# Altium schematic sheet lv_power_tec_cc.SchDoc(lv_power_tec_cc)
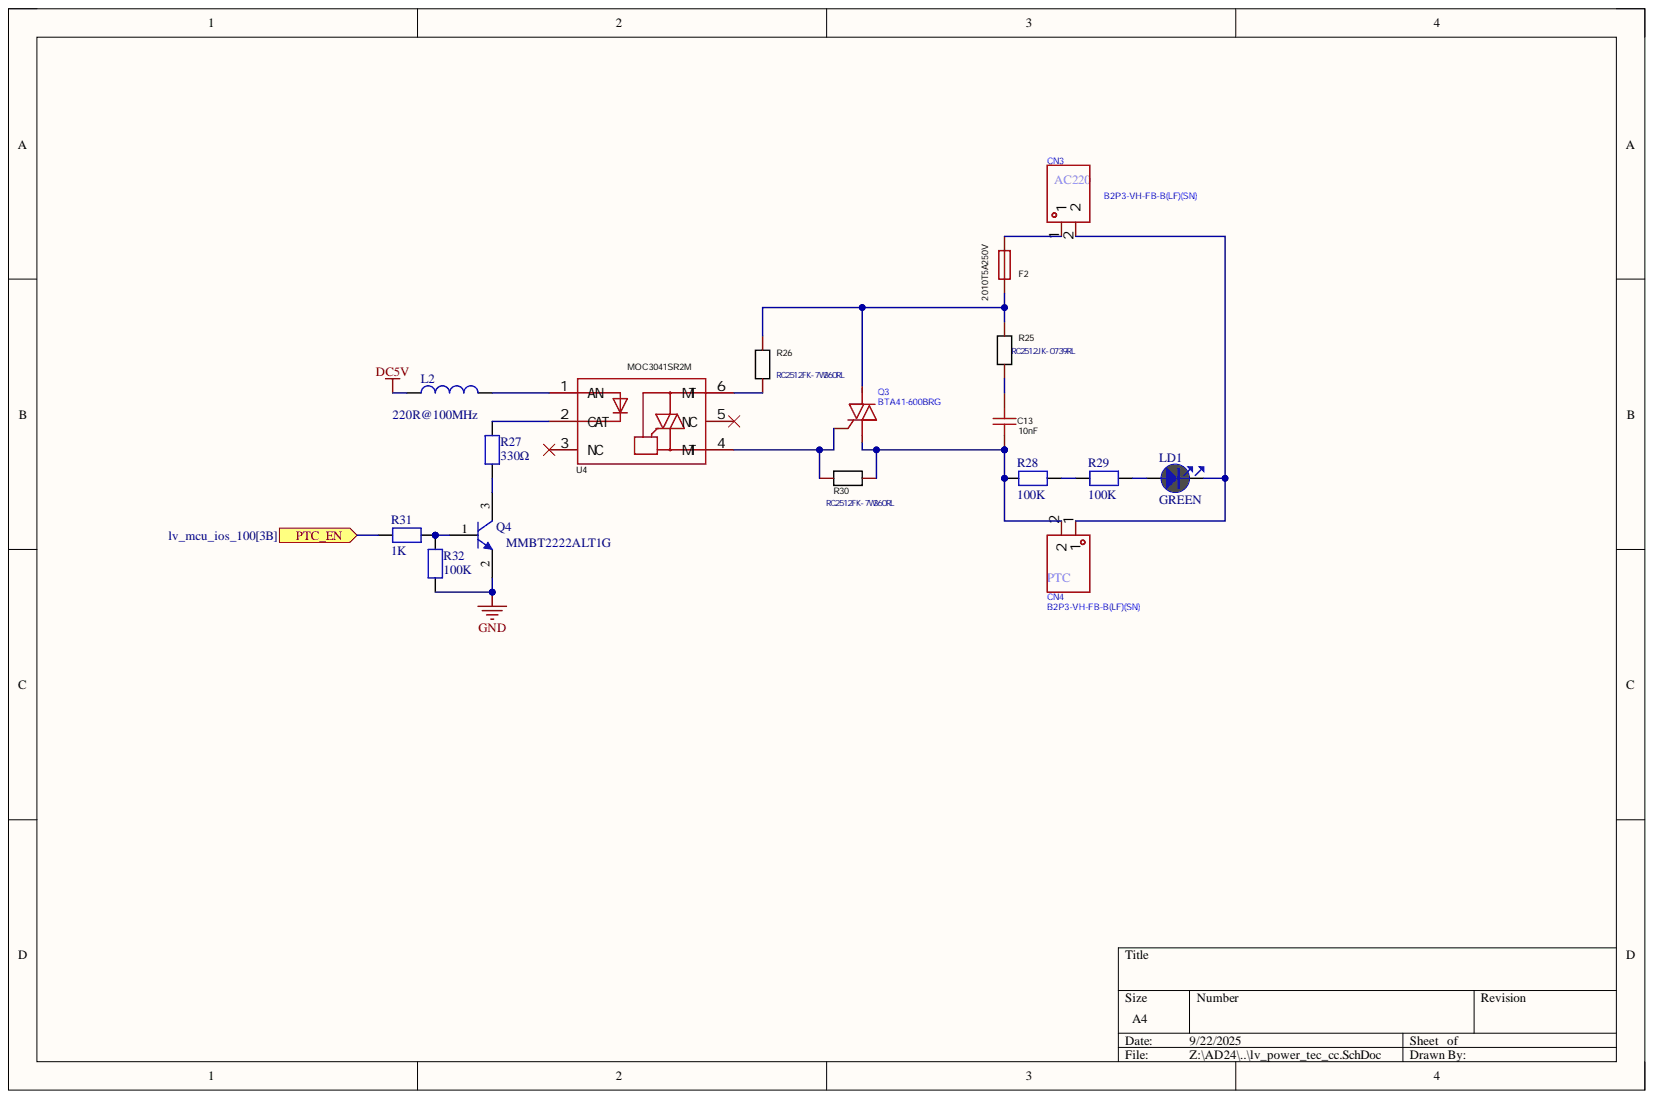
[diagram p0: lv_power_tec_cc.SchDoc]

## Parts
C13  10nF  [CAP-TH_L10.0-W6.0-P7.50-D0.6]
CN3  B2P3-VH-FB-B(LF)(SN)  [CONN-TH_2P-P7.92_B2P3-VH-FB-B-LF-SN]
CN4  B2P3-VH-FB-B(LF)(SN)  [CONN-TH_2P-P7.92_B2P3-VH-FB-B-LF-SN]
F2  2010T5A250V  [FUSE-TH_L8.5-W4.0-P5.08-D0.6]
L2  220R@100MHz  [L0603]
LD1  value GREEN  [LED_0603_GREEN]  (hidden comment: LED_green)
Q3  BTA41-600BRG  [TOP3_L15.3-W4.5-P5.60-L]
Q4  MMBT2222ALT1G  [NPN_SOT23]
R25  RC2512JK-0739RL  [R2512]
R26  RC2512FK-7W360RL  [R2512]
R27  330Ω  [R0603]
R28  100K  [C1210]
R29  100K  [C1210]
R30  RC2512FK-7W360RL  [R2512]
R31  1K  [R0402]
R32  100K  [R0402]
U4  MOC3041SR2M  [SOP-6_L8.5-W6.4-P2.54-LS9.2-BL]

## Ports
PTC_EN

## Nets
DC5V: L2.2
GND: Q4.2 R32.1
PTC_EN: R31.1 ; port PTC_EN
NetC13_1: C13.1 CN4.2 Q3.1 R28.1 R30.2
NetC13_2: C13.2 R25.2
NetCN3_1: CN3.1 F2.2
NetCN3_2: CN3.2 CN4.1 LD1.2
NetF2_1: F2.1 Q3.2 R25.1 R26.2
NetL2_1: L2.1 U4.1(AN)
NetLD1_1: LD1.1 R29.2
NetQ3_3: Q3.3 R30.1 U4.4(MT)
NetQ4_1: Q4.1 R31.2 R32.2
NetQ4_3: Q4.3 R27.2
NetR26_1: R26.1 U4.6(MT)
NetR27_1: R27.1 U4.2(CAT)
NetR28_2: R28.2 R29.1

Not connected: U4 3(NC) 5(NC)

## Library data of the parts
C13 = MMK103J2JB5KN206G0: CBB, 630V, 5%; Manufacturer: KNSCHA(科尼盛); Add into BOM: yes; Component Kind: Standard; Convert to PCB: yes; Datasheet: https://atta.szlcsc.com/upload/public/pdf/source/20220419/DB24DB252E6F1323EAB18A483F3A5AEF.pdf; Device: MMK103J2JB5KN206G0; JLCPCB Part Class: Extended Part; LCSC Part Name: 10nF ±5% 630V; Library Name: HiPin_Library_AD.IntLib; Manufacturer Part: MMK103J2JB5KN206G0; Operating Temperature: -40℃~+105℃; PCB3D: CAP-TH_L10.0-W6.0-P7.50-D0.6; Supplier: LCSC; Supplier Footprint: 插件,P=7.5mm; Supplier Part: C2998029; Symbol: MMK103J2JB5KN206G0; Tolerance: ±5%; Value: 10nF; Voltage Rated: 630V
CN3 CN4 = B2P3-VH-FB-B(LF)(SN): 7.9mm*2p; Manufacturer: JST; Add into BOM: yes; Current Rating: 10A; Flame Retardant Rating: UL94V-0; Number of PINs Per Row: 3; Pins Structure: 1x3P(缺1P); Pitch: 7.92mm; Plastic Material: 玻纤增强PBT; Reference Series: VH; Supplementary Features: 带柱；缺PIN; Symbol: B2P3-VH-FB-B-(LF)(SN); Voltage Rating: 250V; X-Length of Bottom Edge on Board (Spacing Line): 13.76mm; Y-Width of Bottom Edge on Board: 9.7mm; Z-Height of the Board: 11mm; Color: 白色; Component Kind: Standard; Contact Material: 铜合金; Contact Plating: 锡; Convert to PCB: yes; Datasheet: https://item.szlcsc.com/datasheet/B2P3-VH-FB-B(LF)(SN)/169357.html; Device: B2P3-VH-FB-B(LF)(SN); JLCPCB Part Class: Extended Part; LCSC Part Name: 1x3P(缺1P) 间距:7.92mm 直插 系列:VH; Library Name: HiPin_Library_AD.IntLib; Manufacturer Part: B2P3-VH-FB-B(LF)(SN); Mounting Type: 直插; Number of Pins: 2P(3P缺1P); Number of Rows: 1; Operating Temperature: -25℃~+85℃; PCB3D: CONN-TH_2P-P7.92_B2P3-VH-FB-B-LF-SN; Row Spacing: -; Supplier: LCSC; Supplier Footprint: 插件,P=7.92mm; Supplier Part: C158009
F2 = 2010T3.15A250V: 保险丝; Manufacturer: WALTER(华德); Add into BOM: yes; Current Rating: 3.15A; Type: 方形保险丝; Breaking Capacity: -; Clearing Time Characteristics: -; Component Kind: Standard; Convert to PCB: yes; Datasheet: https://atta.szlcsc.com/upload/public/pdf/source/20181226/C354908_56D0A636A97884A85D4A44B7DA5ABF9D.pdf; Device: 2010T3.15A250V; JLCPCB Part Class: Extended Part; LCSC Part Name: 插件式保险丝; Library Name: HiPin_Library_AD.IntLib; Manufacturer Part: 2010T3.15A250V; Operating Temperature: -; PCB3D: FUSE-TH_L8.5-W4.0-H8.5-P5.08; Supplier: LCSC; Supplier Footprint: 插件; Supplier Part: C354904; Surge and Pulse Current Charactersistics: -; Symbol: 2010T3.15A250V; Voltage Rating  (AC): -; Voltage Rating (DC): -
L2 = MCD-00047: SMD Chip ferrite bead, 220R@100MHz +/-25%,2.2A, 50mohm; Manufacturer: Sunlord; Manufacturer_Part_Number: UPZ1608U221-2R2TF; Database Table Name: MCD_PARTS$; Component Kind: Standard; ComponentLink1Description: Datasheet; ComponentLink1URL: http://tunmcdsvn.tun.st.com/Component_Datasheet_Library/Trunk/Inductors/TaiTech_Bead_FCM1608_series; Internal_Part_Number: MCD-00047; Library Name: MCD_PARTS_database.DbLib
LD1 = LED_green: LED, Green, 0603; Internal_Part_Number: MCD-00050; Manufacturer: EVERLIGHT; Manufacturer_Part_Number: 19-213SYGC/S530-E2/TR8; Part_Type: Display; ECCN_EU: NEC; ECCN_US: EAR99; Provided_By: SUBCO; Component Kind: Standard; ComponentLink1Description: Datasheet; ComponentLink1URL: http://tunmcdsvn.tun.st.com/Component_Datasheet_Library/Trunk/Display/Everlight_SMD-LED-GREEN_19-213SYGC-S530-XX-TR8-v3.doc; Library Name: mb1367.IntLib; Package: LED 0603; Status: Released
Q3 = BTA41-600BRG_C9103: 可控硅; Manufacturer: ST(意法半导体); Add into BOM: yes; Gate Trigger   Voltage (Vgt): 1.3V; Gate Trigger Current(Igt): 50mA; Holding Current (Ih): 80mA; Peak Repetitive Off?State Voltage (Vdrm): 600V; RMS On-State Current(It (rms)): 40A; Component Kind: Standard; Convert to PCB: yes; Datasheet: https://atta.szlcsc.com/upload/public/pdf/source/20180517/C221478_6AA6A4FEA4D04549221AAA28B5A1E881.pdf; Device: BTA41-600BRG_C9103; JLCPCB Part Class: Extended Part; LCSC Part Name: BTA41-600BRG; Library Name: HiPin_Library_AD.IntLib; Manufacturer Part: BTA41-600BRG; Operating Temperature: -40℃~+125℃@(Tj); PCB3D: TOP3_L15.3-W4.5-H12.8-P5.60; SCR Type: -; Supplier: LCSC; Supplier Footprint: TOP-3; Supplier Part: C9103; Symbol: BTA41-600BRG
Q4 = 9013-SOT23: 晶体管类型  NPN  集电极电流(Ic)  600mA  集射极击穿电压(Vceo)  40V  耗散功率(Pd)  225mW; Manufacturer: onsemi(安森美); Component Kind: Standard; Library Name: HiPin_Library_AD.IntLib; Manufacturer_Part_Number: MMBT2222ALT1G
R25 = PA2512FKE070R005E: 阻值: 39Ω  精度: ±5%  功率: 1W; Manufacturer: YAGEO(国巨); Add into BOM: yes; Name: 5mΩ; Temperature Coefficient: ±50ppm/℃; Tolerance: ±1%; Value: 5mΩ; Component Kind: Standard; Convert to PCB: yes; Datasheet: https://atta.szlcsc.com/upload/public/pdf/source/20201103/C914837_AD836BF5B6C45A77321B881F1772864D.pdf; Device: PA2512FKE070R005E; JLCPCB Part Class: Extended Part; LCSC Part Name: 5mΩ ±1% 1W; Library Name: HiPin_Library_AD.IntLib; Manufacturer Part: PA2512FKE070R005E; Operating Temperature Range: -55℃~+170℃; PCB3D: RES-SMD_L6.4-W3.2_C500733; Power(Watts): 1W; Supplier: LCSC; Supplier Footprint: 2512; Supplier Part: C914837; Symbol: PA2512FKE070R005E
R26 R30 = PA2512FKE070R005E: 阻值: 360Ω  精度: ±1%  功率: 2W; Manufacturer: YAGEO(国巨); Add into BOM: yes; Name: 5mΩ; Power(Watts): 1W; Temperature Coefficient: ±50ppm/℃; Value: 5mΩ; Component Kind: Standard; Convert to PCB: yes; Datasheet: https://atta.szlcsc.com/upload/public/pdf/source/20201103/C914837_AD836BF5B6C45A77321B881F1772864D.pdf; Device: PA2512FKE070R005E; JLCPCB Part Class: Extended Part; LCSC Part Name: 5mΩ ±1% 1W; Library Name: HiPin_Library_AD.IntLib; Manufacturer Part: PA2512FKE070R005E; Operating Temperature Range: -55℃~+170℃; PCB3D: RES-SMD_L6.4-W3.2_C500733; Supplier: LCSC; Supplier Footprint: 2512; Supplier Part: C914837; Symbol: PA2512FKE070R005E; Tolerance: ±1%
R27 R31 R32 = RES: 1%; Value: 10K; Component Kind: Standard; Library Name: HiPin_Library_AD.IntLib
R28 R29 = RES: 1%; Component Kind: Standard; Library Name: HiPin_Library_AD.IntLib; Value: 100K
U4 = MOC3041SR2M: 双向可控硅 有过零电路; Manufacturer: onsemi(安森美); Add into BOM: yes; Forward Current: 60mA; Forward Voltage: 1.25V; Isolation Voltage(rms): 4.17kV; Load Voltage: 400V; Number of Outputs: 1; Static dv/dt: 1000V/us; Zero Crossing Circuit: 有; Component Kind: Standard; Convert to PCB: yes; Datasheet: https://atta.szlcsc.com/upload/public/pdf/source/20150124/1457707262814.pdf; Device: MOC3041SR2M; JLCPCB Part Class: Extended Part; LCSC Part Name: 双向可控硅 有过零电路 1通道; Library Name: HiPin_Library_AD.IntLib; Manufacturer Part: MOC3041SR2M; Operating Temperature: -40℃~+85℃; PCB3D: SOP-6_L8.5-W6.4-H5.1-LS9.9-P2.54; RMS On-State Current(It(rms)): -; SCR Type: 双向可控硅; Supplier: LCSC; Supplier Footprint: SOP-6-2.54mm; Supplier Part: C33904; Symbol: MOC3041SR2M
